# Altium schematic sheet Main.SchDoc(Main)
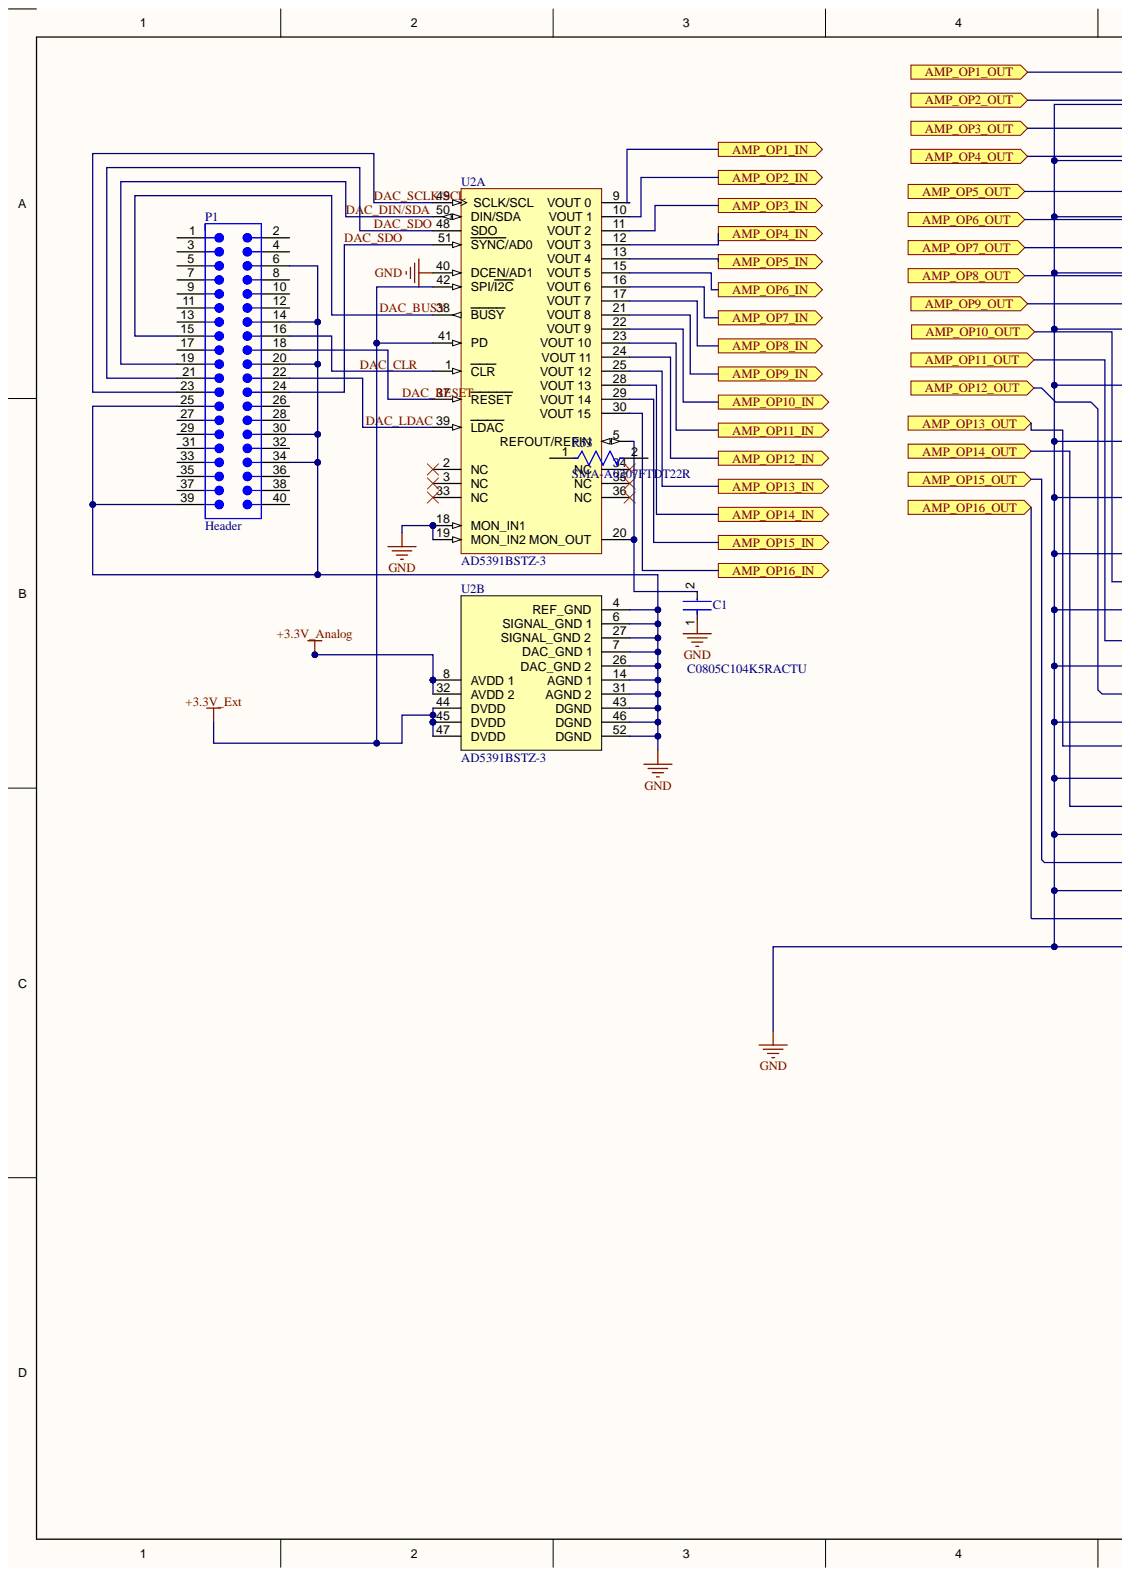
[diagram p0: Main.SchDoc, left]
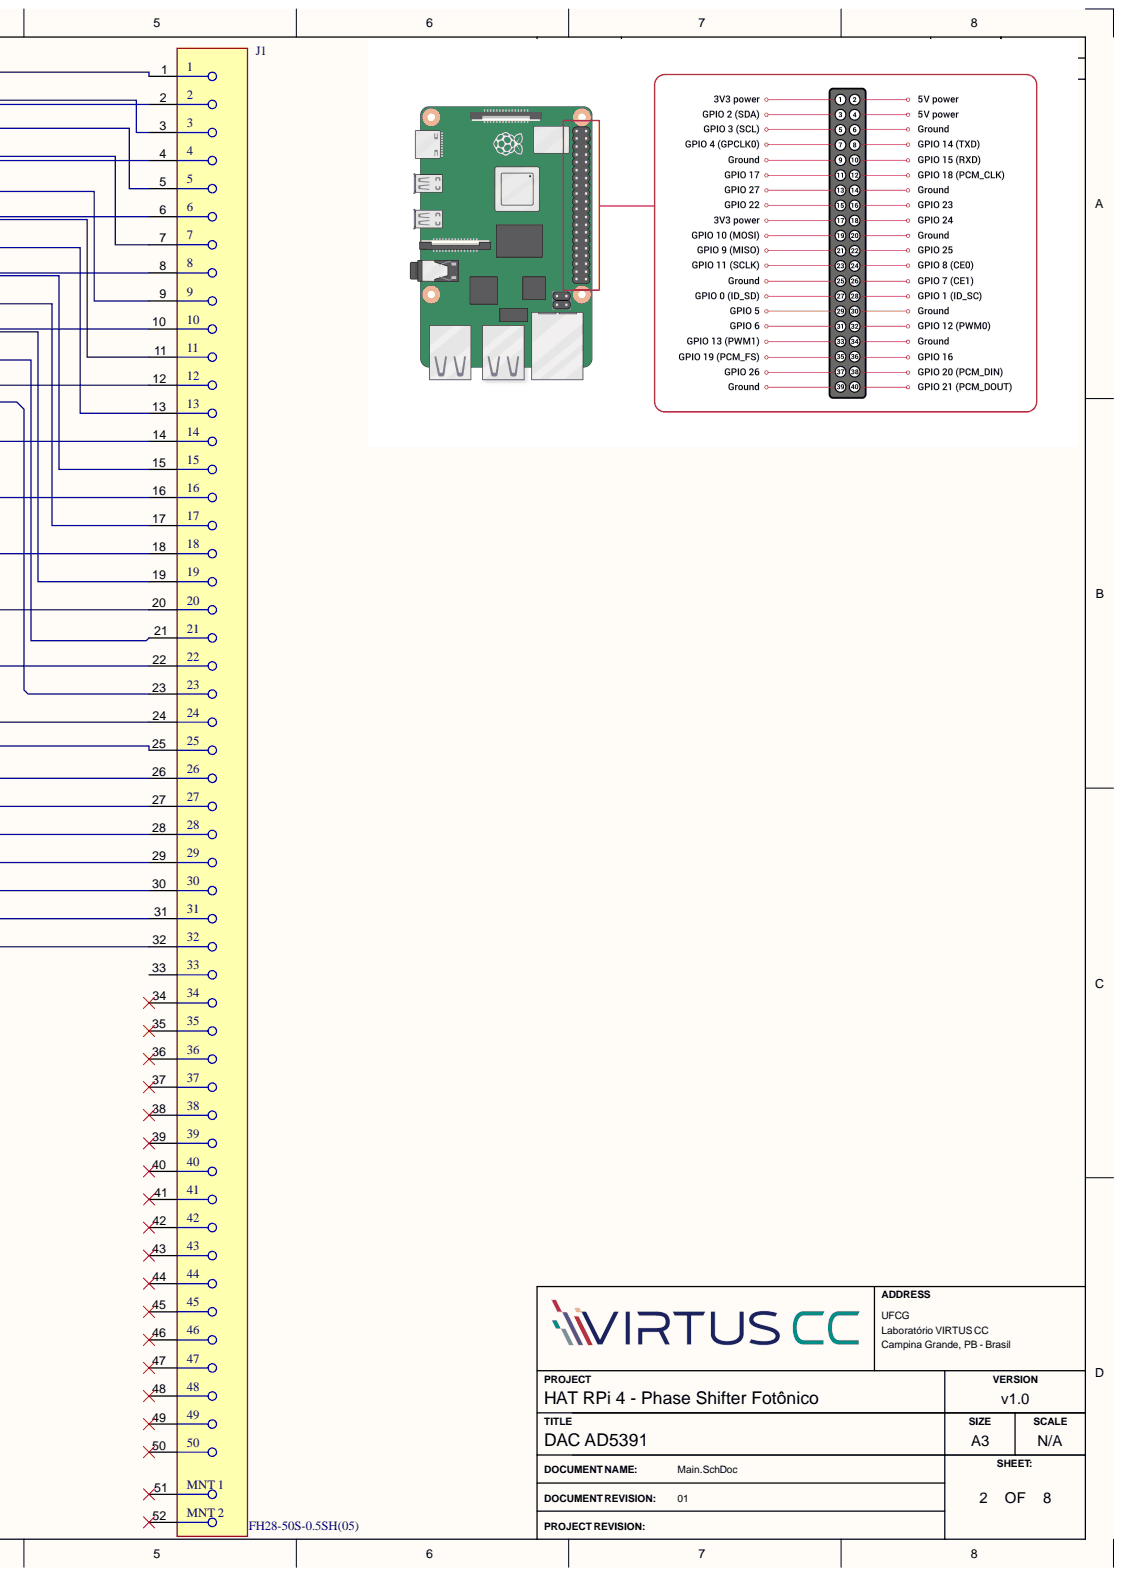
[diagram p1: Main.SchDoc, right]

## Parts
C1  C0805C104K5RACTU  [FP-C0805C-DN-MFG]
J1  FH28-50S-0.5SH(05)  [FP-FH28-50S-0_5SH_05-MFG]
P1  Header  [61204021621]
R53  SMA-A0207FTDT22R  [FP-SMA-A0207-MFG]
U2  AD5391BSTZ-3  [ST-52_L]  (parts A,B)

## Ports
AMP_OP1_IN, AMP_OP1_OUT, AMP_OP2_IN, AMP_OP2_OUT, AMP_OP3_IN, AMP_OP3_OUT, AMP_OP4_IN, AMP_OP4_OUT, AMP_OP5_IN, AMP_OP5_OUT, AMP_OP6_IN, AMP_OP6_OUT, AMP_OP7_IN, AMP_OP7_OUT, AMP_OP8_IN, AMP_OP8_OUT, AMP_OP9_IN, AMP_OP9_OUT, AMP_OP10_IN, AMP_OP10_OUT, AMP_OP11_IN, AMP_OP11_OUT, AMP_OP12_IN, AMP_OP12_OUT, AMP_OP13_IN, AMP_OP13_OUT, AMP_OP14_IN, AMP_OP14_OUT, AMP_OP15_IN, AMP_OP15_OUT, AMP_OP16_IN, AMP_OP16_OUT

## Nets
+3.3V_Analog: U2.8(AVDD_1) U2.32(AVDD_2)
+3.3V_Ext: U2.41(PD) U2.42(SPI/I2C) U2.44(DVDD) U2.45(DVDD) U2.47(DVDD)
GND: C1.1 J1.2 J1.4 J1.6 J1.8 J1.10 J1.12 J1.14 J1.16 J1.18 J1.20 J1.22 J1.24 J1.26 J1.28 J1.30 J1.32 P1.6 P1.14 P1.20 P1.25 P1.30 P1.34 P1.39 U2.4(REF_GND) U2.6(SIGNAL_GND_1) U2.7(DAC_GND_1) U2.14(AGND_1) U2.18(MON_IN1) U2.19(MON_IN2) U2.26(DAC_GND_2) U2.27(SIGNAL_GND_2) U2.31(AGND_2) U2.40(DCEN/AD1) U2.43(DGND) U2.46(DGND) U2.52(DGND)
AMP_OP1_IN: U2.9(VOUT_0) ; port AMP_OP1_IN
AMP_OP1_OUT: J1.1 ; port AMP_OP1_OUT
AMP_OP2_IN: U2.10(VOUT_1) ; port AMP_OP2_IN
AMP_OP2_OUT: J1.3 ; port AMP_OP2_OUT
AMP_OP3_IN: U2.11(VOUT_2) ; port AMP_OP3_IN
AMP_OP3_OUT: J1.5 ; port AMP_OP3_OUT
AMP_OP4_IN: U2.12(VOUT_3) ; port AMP_OP4_IN
AMP_OP4_OUT: J1.7 ; port AMP_OP4_OUT
AMP_OP5_IN: U2.13(VOUT_4) ; port AMP_OP5_IN
AMP_OP5_OUT: J1.9 ; port AMP_OP5_OUT
AMP_OP6_IN: U2.15(VOUT_5) ; port AMP_OP6_IN
AMP_OP6_OUT: J1.11 ; port AMP_OP6_OUT
AMP_OP7_IN: U2.16(VOUT_6) ; port AMP_OP7_IN
AMP_OP7_OUT: J1.13 ; port AMP_OP7_OUT
AMP_OP8_IN: U2.17(VOUT_7) ; port AMP_OP8_IN
AMP_OP8_OUT: J1.15 ; port AMP_OP8_OUT
AMP_OP9_IN: U2.21(VOUT_8) ; port AMP_OP9_IN
AMP_OP9_OUT: J1.17 ; port AMP_OP9_OUT
AMP_OP10_IN: U2.22(VOUT_9) ; port AMP_OP10_IN
AMP_OP10_OUT: J1.19 ; port AMP_OP10_OUT
AMP_OP11_IN: U2.23(VOUT_10) ; port AMP_OP11_IN
AMP_OP11_OUT: J1.21 ; port AMP_OP11_OUT
AMP_OP12_IN: U2.24(VOUT_11) ; port AMP_OP12_IN
AMP_OP12_OUT: J1.23 ; port AMP_OP12_OUT
AMP_OP13_IN: U2.25(VOUT_12) ; port AMP_OP13_IN
AMP_OP13_OUT: J1.25 ; port AMP_OP13_OUT
AMP_OP14_IN: U2.28(VOUT_13) ; port AMP_OP14_IN
AMP_OP14_OUT: J1.27 ; port AMP_OP14_OUT
AMP_OP15_IN: U2.29(VOUT_14) ; port AMP_OP15_IN
AMP_OP15_OUT: J1.29 ; port AMP_OP15_OUT
AMP_OP16_IN: U2.30(VOUT_15) ; port AMP_OP16_IN
AMP_OP16_OUT: J1.31 ; port AMP_OP16_OUT
DAC_BUSY: P1.15 U2.38(BUSY)
DAC_CLR: P1.16 U2.1(CLR)
DAC_DIN/SDA: P1.19 U2.50(DIN/SDA)
DAC_LDAC: P1.22 U2.39(LDAC)
DAC_RESET: P1.18 U2.37(RESET)
DAC_SCLK/SCL: P1.23 U2.49(SCLK/SCL)
DAC_SDO: P1.21 P1.24 U2.48(SDO) U2.51(SYNC/AD0)
NetC1_2: C1.2 U2.5(R53) U2.20(MON_OUT)

Not connected: J1 33 34 35 36 37 38 39 40 41 42 43 44 45 46 47 48 49 50 51 52; P1 1 2 3 4 5 7 8 9 10 11 12 13 17 26 27 28 29 31 32 33 35 36 37 38 40; R53 1 2; U2 2(NC) 3(NC) 33(NC) 34(NC) 35(NC) 36(NC)

## Library data of the parts
C1 = CMP-2007-00279-2: CAP CER 0.1UF 50V X7R 0805; Manufacturer: KEMET; Capacitance: 0.10 μF; Material: Ceramic; Temperature Coefficient: 30ppm/°C; Tolerance: 0.1; Value: 0.10 μF; Automotive: No; Category: Cap; Component Kind: Standard; ComponentLink2Description: Datasheet; ComponentLink2URL: https://content.kemet.com/datasheets/KEM_C1003_C0G_SMD.pdf; Device Class L1: Passive Components; Device Class L2: Capacitors; Device Class L3: Ceramic Capacitors; Digikey Description: CAP CER 0.1UF 50V X7R 0805; Height: 0.88mm; Ipc Land Pattern Name: CAPC200125X78; Lead Free: Yes; Library Name: Altium Content Vault; Manufacturer Part Number: C0805C104K5RACTU; Mouser Description: Cap Ceramic 0.1uF 50V X7R 10% SMD 2.0 x 1.2 mm 125 C Paper T/R; Octopart: https://octopart.com/c0805c104k5ractu-kemet-143926; Package: C0805C-DN; RoHS: TRUE; Temperature: -55°C to +125°C; Temperature Characteristic: X7R; Temperature Range High: +125°C; Temperature Range Low: -55°C; Voltage Rating: 50 V
J1 = CMP-11609-000009-1: CONN FFC BOTTOM 50POS 0.50MM R/A; Manufacturer: Hirose Electric Co Ltd; Conn Gender: Female; Conn Orientation: Horizontal; Current Rating: 0.5A; Is Female: yes; Number Of Contacts: 50; Pitch: 0.5mm; Voltage Rating: 50V; Automotive: No; Category: Conn; Component Kind: Standard; ComponentLink2Description: Datasheet; ComponentLink2URL: http://www.hirose.co.jp/cataloge_hp/e58618038.pdf; Contact Material: Gold; Device Class L1: Connectors; Device Class L2: FFC / FPC; Device Class L3: unset; Digikey Description: CONN FFC BOTTOM 50POS 0.50MM R/A; Height: 5.6mm; Lead Free: Yes; Library Name: Altium Content Vault; Manufacturer Part Number: FH28-50S-0.5SH(05); Mouser Description: FFC & FPC Connectors 50POS 0.5MM SMT GOLD; Octopart: https://octopart.com/fh28-50s-0.5sh%2805%29-hirose-240232; Package: 0; RoHS: TRUE; Temperature: -40°C to +105°C; Temperature Range High: +105°C; Temperature Range Low: -40°C
P1 = CMP-1502-00015-4: WR-BHD Straight Male, Box Header, Through Hole, 2 Rows, 40 Contacts, 2.54mm Pitch; Connector Type: Male Pin; Contact Resistance: 20mΩ; Current Rating: 3A; Insulation Resistance: 1GΩ; Number of Contacts: 40; Operating Supply Voltage: 250V; Color: Black; Component Kind: Standard; Contact Material: Gold; Contact Plating: Gold; Gender: Male; Height: 9.25mm; Insulation Material: PBT; Lead Pitch: 2.54mm; Length: 58.46mm; Library Name: Altium Content Vault; Max Operating Temperature: 105°C; Min Operating Temperature: -40°C; Mount: Through Hole; Number of Pins: 40; Number of Positions: 40; Number of Rows: 2; Orientation: Vertical; Pitch: 2.54mm; REACH SVHC: Unknown; RoHS: Compliant; Row Spacing: 2.54mm; Termination: Solder; Voltage Rating: 250V
R53 = CMP-03211-006581-1: SMA-A 0207 22Ω 1W 50ppm/ºC 1.00% 350V; Manufacturer: TE Connectivity; Automotive Grade: Grade 0; Tolerance: 0.01; Automotive: Yes; Category: Res; Component Kind: Standard; ComponentLink2Description: Datasheet; ComponentLink2URL: https://www.te.com/commerce/DocumentDelivery/DDEController?Action=showdoc&DocId=Data+Sheet%7F6-1773446-2%7F1%7Fpdf%7FEnglish%7FENG_DS_6-1773446-2_1.pdf%7F3-2176316-7551; Composition: Metal Film; Device Class L1: Passive Components; Device Class L2: Resistors; Device Class L3: Chip SMD Resistors; Footprint Url: https://www.te.com/commerce/DocumentDelivery/DDEController?Action=showdoc&DocId=Customer+Drawing%7F2176316%7FBA%7Fpdf%7FEnglish%7FENG_CD_2176316_BA.pdf%7F3-2176316-7551; Height: 2.4mm; Lead Free: Yes; Library Name: Altium Content Vault; Manufacturer Part Number: SMA-A0207FTDT22R; Octopart: https://octopart.com/sma-a0207ftdt22r-te+connectivity-89729466; Package: SMA-A0207; Power Rating: 1W; Resistance: 22Ω; RoHS: TRUE; Series: SMA-A; Temperature: -55°C to +155°C; Temperature Coefficient: 50ppm/ºC; Temperature Range High: +155°C; Temperature Range Low: -55°C; Value: 22Ω; Voltage Rating: 350V
U2 = CMP-0318-00791-2: 16 Channel 12-Bit Single-Supply Voltage Output Digital-to-Analog Converter with Serial Interface, 3 V, -40 to +85 degC, ST-52, Pb-Free, Tray; Generic Part Number: AD5391; Manufacturer: Analog Devices; # DAC Outputs: 16; Max V+: 5.5V; Resolution (Bits): 12; Settling Time: 6Âµs; Single Supply: Yes; Code_JEDEC: MS-026-BCC; Component Kind: Standard; ComponentLink1Description: Manufacturer URL; ComponentLink1URL: http://www.analog.com/en/index.html; ComponentLink2Description: Datasheet; ComponentLink2URL: http://www.analog.com/static/imported-files/data_sheets/AD5390_5391_5392.pdf; ComponentLink3Description: Package Specification; ComponentLink3URL: http://www.analog.com/static/imported-files/packages/61012411624300842189414155189544791816408612ST_52_10x10.pdf; ComponentLink4Description: Product URL; ComponentLink4URL: http://www.analog.com/en/digital-to-analog-converters/da-converters/ad5391/products/product.html; ComponentLink5Description: Reference Design URL; ComponentLink5URL: http://www.analog.com/en/digital-to-analog-converters/da-converters/ad5391/products/product.html#prod_cross_sell; DatasheetVersion: Rev. D; Library Name: Altium Content Vault; Mounting Technology: Surface Mount; PackageDescription: 52-Lead Low Profile Quad Flat Package [LQFP]; PackageReference: ST-52; PackageVersion: 2003; Packing: Tray; PartNumber: AD5391BSTZ-3; RevisionNote: Component URL fixed; RoHS: Y
